# Altium schematic sheet Puente H.SchDoc
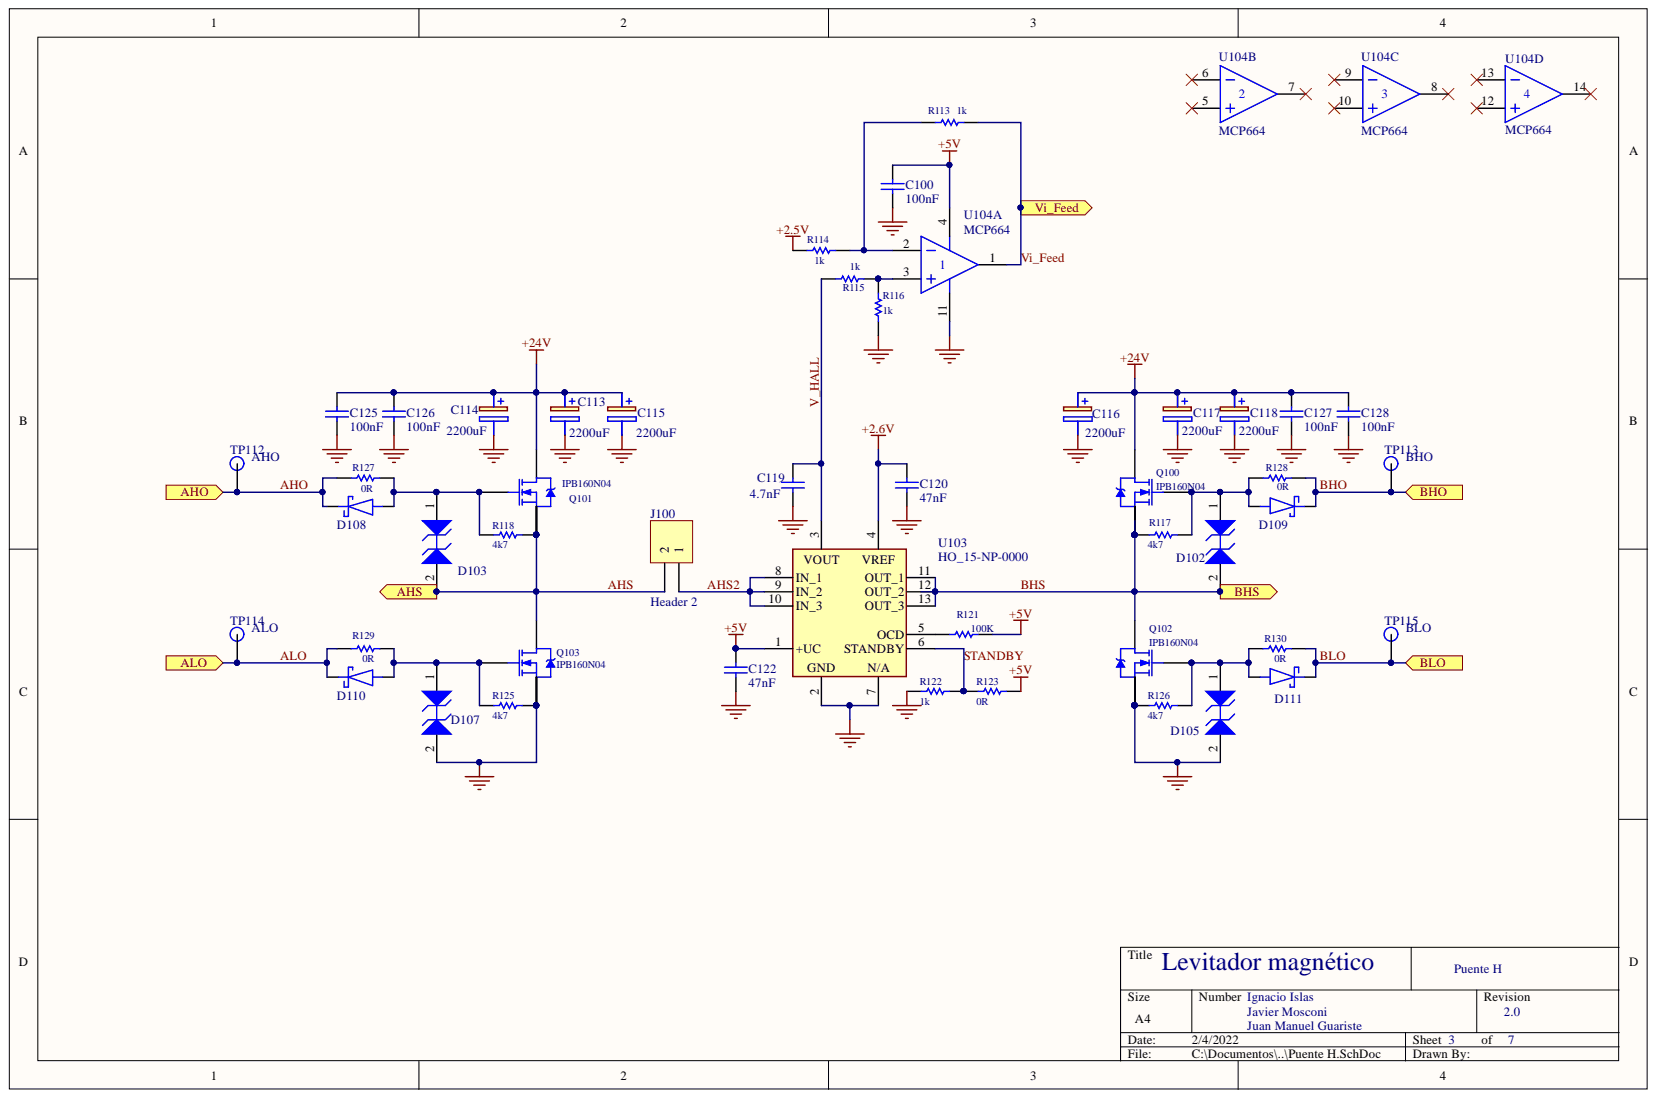
[diagram p0: Puente H.SchDoc]

## Parts
C100  value 100nF  [C1206]  (hidden comment: Capacitor)
C113  value 2200uF  [CAPPRD500W60D1300H2650]  (hidden comment: EKY-350ELL102MK25S)
C114  value 2200uF  [CAPPRD500W60D1300H2650]  (hidden comment: EKY-350ELL102MK25S)
C115  value 2200uF  [CAPPRD500W60D1300H2650]  (hidden comment: EKY-350ELL102MK25S)
C116  value 2200uF  [CAPPRD500W60D1300H2650]  (hidden comment: EKY-350ELL102MK25S)
C117  value 2200uF  [CAPPRD500W60D1300H2650]  (hidden comment: EKY-350ELL102MK25S)
C118  value 2200uF  [CAPPRD500W60D1300H2650]  (hidden comment: EKY-350ELL102MK25S)
C119  value 4.7nF  [C1206]  (hidden comment: Capacitor)
C120  value 47nF  [C1206]  (hidden comment: Capacitor)
C122  value 47nF  [C1206]  (hidden comment: Capacitor)
C125  value 100nF  [C1206]  (hidden comment: Capacitor)
C126  value 100nF  [C1206]  (hidden comment: Capacitor)
C127  value 100nF  [C1206]  (hidden comment: Capacitor)
C128  value 100nF  [C1206]  (hidden comment: Capacitor)
D102  SMAJ15A  [ONSC-SMA-2-403D-02_F_V]  (value not drawn)
D103  SMAJ15A  [ONSC-SMA-2-403D-02_F_V]  (value not drawn)
D105  SMAJ15A  [ONSC-SMA-2-403D-02_F_V]  (value not drawn)
D107  SMAJ15A  [ONSC-SMA-2-403D-02_F_V]  (value not drawn)
D108  RSX205LAM30TR  [SODFL4725X105N]  (value not drawn)
D109  RSX205LAM30TR  [SODFL4725X105N]  (value not drawn)
D110  RSX205LAM30TR  [SODFL4725X105N]  (value not drawn)
D111  RSX205LAM30TR  [SODFL4725X105N]  (value not drawn)
J100  Header 2  [SMKDS5-2-9.5]
Q100  IPB160N04  [TO263-7S]
Q101  IPB160N04  [TO263-7S]
Q102  IPB160N04  [TO263-7S]
Q103  IPB160N04  [TO263-7S]
R113  1k  [R1206]
R114  1k  [R1206]
R115  1k  [R1206]
R116  1k  [R1206]
R117  4k7  [R1206]
R118  4k7  [R1206]
R121  100K  [R1206]
R122  1k  [R1206]
R123  0R  [R1206]
R125  4k7  [R1206]
R126  4k7  [R1206]
R127  0R  [R1206]
R128  0R  [R1206]
R129  0R  [R1206]
R130  0R  [R1206]
TP112  AHO  [TestPoint Keystone 5000 Silver-Red]
TP113  BHO  [TestPoint Keystone 5000 Silver-Red]
TP114  ALO  [TestPoint Keystone 5000 Silver-Red]
TP115  BLO  [TestPoint Keystone 5000 Silver-Red]
U103  HO_15-NP-0000  [HO15NP0000]
U104  MCP664  [SOIC14]  (parts A,B,C,D)

## Ports
AHO, AHS, ALO, BHO, BHS, BLO, Vi_Feed

## Nets
+2.5V: R114.1
+2.6V: C120.1 U103.4
+5V: C100.1 C122.2 R121.2 R123.1 U103.1(+UC) U104.4
+24V: C113.1 C114.1 C115.1 C116.1 C117.1 C118.1 C125.2 C126.2 C127.2 C128.2 Q100.4 Q101.4
GND: C100.2 C113.2 C114.2 C115.2 C116.2 C117.2 C118.2 C119.1 C120.2 C122.1 C125.1 C126.1 C127.1 C128.1 D105.2 D107.2 Q102.2 Q102.3 Q102.5 Q102.6 Q102.7 Q103.2 Q103.3 Q103.5 Q103.6 Q103.7 R116.1 R122.2 R125.2 R126.1 U103.2 U103.7 U104.11
AHO: D108.1 R127.1 TP112.1 ; port AHO
AHS: D103.2 J100.2 Q101.2 Q101.3 Q101.5 Q101.6 Q101.7 Q103.4 R118.2 ; port AHS
AHS2: J100.1 U103.8(IN_1) U103.9(IN_2) U103.10(IN_3)
ALO: D110.1 R129.1 TP114.1 ; port ALO
BHO: D109.1 R128.2 TP113.1 ; port BHO
BHS: D102.2 Q100.2 Q100.3 Q100.5 Q100.6 Q100.7 Q102.4 R117.1 U103.11(OUT_1) U103.12(OUT_2) U103.13(OUT_3) ; port BHS
BLO: D111.1 R130.2 TP115.1 ; port BLO
STANDBY: R122.1 R123.2 U103.6(STANDBY)
V_HALL: C119.2 R115.1 U103.3
Vi_Feed: R113.2 U104.1 ; port Vi_Feed
NetD102_1: D102.1 D109.2 Q100.1 R117.2 R128.1
NetD103_1: D103.1 D108.2 Q101.1 R118.1 R127.2
NetD105_1: D105.1 D111.2 Q102.1 R126.2 R130.1
NetD107_1: D107.1 D110.2 Q103.1 R125.1 R129.2
NetR113_1: R113.1 R114.2 U104.2
NetR115_2: R115.2 R116.2 U104.3
NetR121_1: R121.1 U103.5(OCD)

Not connected: U104 5 6 7 8 9 10 12 13 14

## Library data of the parts
C100 C125-C128 = Capacitor: CAP CER 0.1UF 50V X7R 1206; Manufacturer: Venkel; Mfr PN: C1206X7R500-104KNE; Component Kind: Standard; Supplier 1: Digi-Key; Supplier 1 PN: 2679-C1206X7R500-104KNETR-ND; Library Name: Levitador_GMI.SchLib
C113-C118 = EKY-350ELL102MK25S: CAP ALUM 2200UF 20% 35V RADIAL; Manufacturer: United Chemi-Con; Mfr PN: EKY-350ELL222MM25S; Component Kind: Standard; Datasheet Link: https://www.digikey.com/en/products/detail/united-chemi-con/EKY-350ELL222MM25S/756106; Supplier 1: Digi-Key; Supplier 1 PN: 565-1590-ND; Library Name: Levitador_GMI.SchLib
C119 = Capacitor: CAP CER 4700PF 200V X7R 1206; Manufacturer: CAL-CHIP ELECTRONICS, INC.; Mfr PN: GMC31X7R472K200NT; Component Kind: Standard; Ssupplier 1: Digi-Key; Supplier 1 PN: 2571-GMC31X7R472K200NTTR-ND; Library Name: Levitador_GMI.SchLib
C120 C122 = Capacitor: CAP CER 0.047UF 50V C0G/NP0 1206; Manufacturer: CAL-CHIP ELECTRONICS, INC.; Mfr PN: GMC31CG473J50NT; Component Kind: Standard; Supplier 1: Digi-Key; Supplier 1 PN: 2571-GMC31CG473J50NTTR-ND; Library Name: Levitador_GMI.SchLib
D102 D103 D105 D107 = 1SMA60CAT3G: TVS DIODE 18VWM 29.2VC DO214AC; Manufacturer: Littelfuse Inc.; Mfr PN: SMAJ18A; Component Kind: Standard; ComponentLink1Description: Manufacturer URL; ComponentLink1URL: http://www.onsemi.com/; ComponentLink2Description: Datasheet; ComponentLink2URL: http://www.onsemi.com/pub_link/Collateral/1SMA10CAT3-D.PDF; ComponentLink3Description: Package Specification; ComponentLink3URL: http://www.onsemi.com/pub_link/Collateral/1SMA5913BT3-D.PDF; Supplier 1: Digi-Key; Supplier 1 PN: SMAJ18ALFCT-ND; Library Name: Levitador_GMI.SchLib
D108-D111 = RSX205LAM30TR: DIODE SCHOTTKY 30V 2A PMDTM; Manufacturer: ROHM Semiconductor; Component Kind: Standard; Datasheet Link: https://fscdn.rohm.com/en/products/databook/datasheet/discrete/diode/schottky_barrier/rsx205lam30tr-e.pdf; Mfr PN: RSX205LAM30TR; Supplier 1: RSX205LAM30CT-ND; Supplier 1 PN: 1.05mm; Library Name: Levitador_GMI.SchLib
J100 = Header 2: TERM BLK 2P SIDE ENT 2.54MM PCB; Manufacturer: TE Connectivity AMP Connectors; Mfr PN: 282834-2; Component Kind: Standard; Link: https://www.digikey.com/en/products/detail/te-connectivity-amp-connectors/282834-2/1150135; Signal Integrity: Connector; Supplier 1: Digi-Key; Supplier 1 PN: A98333-ND; Library Name: Levitador_GMI.SchLib
Q100-Q103 = IPB160N04: MOSFET N-CH 40V 160A TO263-7; Manufacturer: Infineon Technologies; Mfr PN: IPB160N04S3H2ATMA1; Component Kind: Standard; Datasheet: https://www.infineon.com/dgdl/Infineon-IPB160N04S3_H2-DS-v01_00-en.pdf?fileId=db3a304412b407950112b42b8da04548&ack=t; Simulation: IRF630S; Supplier 1: Digi-Key; Supplier 1 PN: IPB160N04S3H2ATMA1CT-ND; Library Name: Levitador_GMI.SchLib
R113-R116 R122 = R1206: RES 1K OHM 1% 1/4W 1206; Manufacturer: Stackpole Electronics Inc; Mfr PN: RMCF1206FT1K00; Component Kind: Standard; PackageReference: 1206; Simulation: RESISTOR; Supplier 1: Digi-Key; Supplier 1 PN: RMCF1206FT1K00CT-ND; Tolerance: 1%; Value: 1k; Library Name: Levitador_GMI.SchLib
R117 R118 R125 R126 = R1206: RES 4.7K OHM 1% 1/4W 1206; Manufacturer: Stackpole Electronics Inc; Mfr PN: RMCF1206FT4K70; Component Kind: Standard; PackageReference: 1206; Simulation: RESISTOR; Supplier 1: Digi-Key; Supplier 1 PN: RMCF1206FT4K70CT-ND; Tolerance: 1%; Value: 4k7; Library Name: Levitador_GMI.SchLib
R121 = R1206: RES 100K OHM 1% 1/4W 1206; Manufacturer: Stackpole Electronics Inc; Mfr PN: RMCF1206FT100K; Component Kind: Standard; PackageReference: 1206; Simulation: RESISTOR; Supplier 1: Digi-Key; Supplier 1 PN: RMCF1206FT100KCT-ND; Tolerance: 1%; Value: 100K; Library Name: Levitador_GMI.SchLib
R123 R127-R130 = R1206: RES 0 OHM JUMPER 1/4W 1206; Manufacturer: Stackpole Electronics Inc; Mfr PN: RMCF1206ZT0R00; Component Kind: Standard; PackageReference: 1206; Simulation: RESISTOR; Supplier 1: Digi-Key; Supplier 1 PN: RMCF1206ZT0R00CT-ND; Value: 0R; Library Name: Levitador_GMI.SchLib
TP112-TP115 = Testpoint: PC TEST POINT MINIATURE RED; Manufacturer: Keystone Electronics; Mfr PN: 5000; Component Kind: Standard; Link: https://www.digikey.com/en/products/detail/keystone-electronics/5000/255326; Supplier 1: Digi-Key; Supplier 1 PN: 36-5000-ND; Library Name: Levitador_GMI.SchLib
U103 = HO_15-NP-0000: SENSOR CURRENT HALL 15A AC/DC; Manufacturer: LEM USA Inc.; Mfr PN: HO 15-NP-0000; Component Kind: Standard; Datasheet Link: http://www.farnell.com/datasheets/2150001.pdf; Supplier 1: Digi-Key; Supplier 1 PN: 398-1142-ND; Library Name: Levitador_GMI.SchLib
U104 = MCP664: IC OPAMP GP 4 CIRCUIT 14TSSOP; Manufacturer: Microchip Technology; Part Number: MCP664-E/ST; Component Kind: Standard; Datasheet: https://ar.mouser.com/datasheet/2/268/20002194E-2448650.pdf; Supplier 1: Digi-Key; Supplier 1 PN: MCP664-E/ST-ND; Library Name: Levitador_GMI.SchLib
